# Altium schematic sheet 10-DashLEDs.SchDoc(10-DashLEDs)
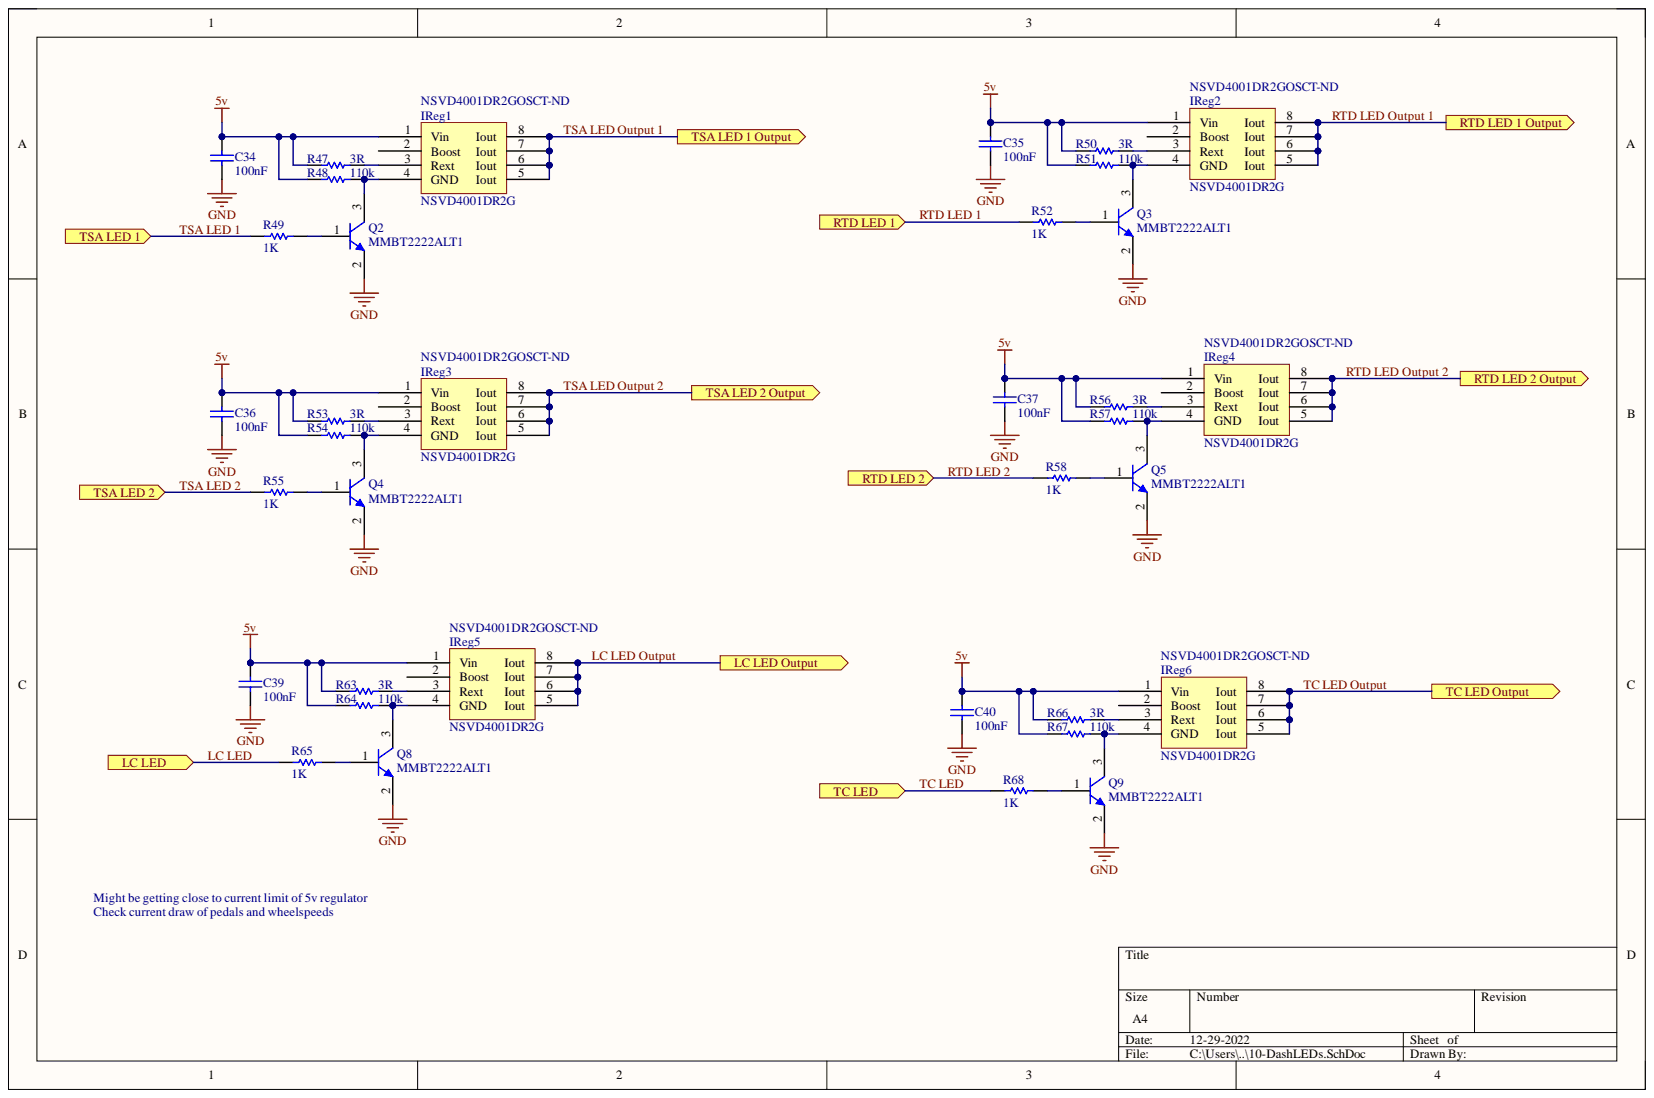
[diagram p0: 10-DashLEDs.SchDoc]

## Parts
C34  value 100nF  [1608[0603]]  (hidden comment: Cap)
C35  value 100nF  [1608[0603]]  (hidden comment: Cap)
C36  value 100nF  [1608[0603]]  (hidden comment: Cap)
C37  value 100nF  [1608[0603]]  (hidden comment: Cap)
C39  value 100nF  [1608[0603]]  (hidden comment: Cap)
C40  value 100nF  [1608[0603]]  (hidden comment: Cap)
IReg1  NSVD4001DR2G  Supplier Part Number 1: NSVD4001DR2GOSCT-ND  [SO8_M]
IReg2  NSVD4001DR2G  Supplier Part Number 1: NSVD4001DR2GOSCT-ND  [SO8_M]
IReg3  NSVD4001DR2G  Supplier Part Number 1: NSVD4001DR2GOSCT-ND  [SO8_M]
IReg4  NSVD4001DR2G  Supplier Part Number 1: NSVD4001DR2GOSCT-ND  [SO8_M]
IReg5  NSVD4001DR2G  Supplier Part Number 1: NSVD4001DR2GOSCT-ND  [SO8_M]
IReg6  NSVD4001DR2G  Supplier Part Number 1: NSVD4001DR2GOSCT-ND  [SO8_M]
Q2  MMBT2222ALT1  [ONSC-SOT-23-3-318-08_V]
Q3  MMBT2222ALT1  [ONSC-SOT-23-3-318-08_V]
Q4  MMBT2222ALT1  [ONSC-SOT-23-3-318-08_V]
Q5  MMBT2222ALT1  [ONSC-SOT-23-3-318-08_V]
Q8  MMBT2222ALT1  [ONSC-SOT-23-3-318-08_V]
Q9  MMBT2222ALT1  [ONSC-SOT-23-3-318-08_V]
R47  value 3R  [1608[0603]]  (hidden comment: Res1)
R48  value 110k  Resistance (Ohms): 110k  [1608[0603]]  (hidden comment: Res1)
R49  value 1K  [1608[0603]]  (hidden comment: Res1)
R50  value 3R  [1608[0603]]  (hidden comment: Res1)
R51  value 110k  Resistance (Ohms): 110k  [1608[0603]]  (hidden comment: Res1)
R52  value 1K  [1608[0603]]  (hidden comment: Res1)
R53  value 3R  [1608[0603]]  (hidden comment: Res1)
R54  value 110k  Resistance (Ohms): 110k  [1608[0603]]  (hidden comment: Res1)
R55  value 1K  [1608[0603]]  (hidden comment: Res1)
R56  value 3R  [1608[0603]]  (hidden comment: Res1)
R57  value 110k  Resistance (Ohms): 110k  [1608[0603]]  (hidden comment: Res1)
R58  value 1K  [1608[0603]]  (hidden comment: Res1)
R63  value 3R  [1608[0603]]  (hidden comment: Res1)
R64  value 110k  Resistance (Ohms): 110k  [1608[0603]]  (hidden comment: Res1)
R65  value 1K  [1608[0603]]  (hidden comment: Res1)
R66  value 3R  [1608[0603]]  (hidden comment: Res1)
R67  value 110k  Resistance (Ohms): 110k  [1608[0603]]  (hidden comment: Res1)
R68  value 1K  [1608[0603]]  (hidden comment: Res1)

## Ports
LC LED, LC LED Output, RTD LED 1, RTD LED 1 Output, RTD LED 2, RTD LED 2 Output, TC LED, TC LED Output, TSA LED 1, TSA LED 1 Output, TSA LED 2, TSA LED 2 Output

## Nets
5v: C34.2 C35.2 C36.2 C37.2 C39.2 C40.2 IReg1.1(Vin) IReg2.1(Vin) IReg3.1(Vin) IReg4.1(Vin) IReg5.1(Vin) IReg6.1(Vin) R47.1 R48.1 R50.1 R51.1 R53.1 R54.1 R56.1 R57.1 R63.1 R64.1 R66.1 R67.1
GND: C34.1 C35.1 C36.1 C37.1 C39.1 C40.1 Q2.2 Q3.2 Q4.2 Q5.2 Q8.2 Q9.2
LC LED: R65.2 ; port LC LED
LC LED Output: IReg5.5(Iout) IReg5.6(Iout) IReg5.7(Iout) IReg5.8(Iout) ; port LC LED Output
RTD LED 1: R52.2 ; port RTD LED 1
RTD LED 2: R58.2 ; port RTD LED 2
RTD LED Output 1: IReg2.5(Iout) IReg2.6(Iout) IReg2.7(Iout) IReg2.8(Iout) ; port RTD LED 1 Output
RTD LED Output 2: IReg4.5(Iout) IReg4.6(Iout) IReg4.7(Iout) IReg4.8(Iout) ; port RTD LED 2 Output
TC LED: R68.2 ; port TC LED
TC LED Output: IReg6.5(Iout) IReg6.6(Iout) IReg6.7(Iout) IReg6.8(Iout) ; port TC LED Output
TSA LED 1: R49.2 ; port TSA LED 1
TSA LED 2: R55.2 ; port TSA LED 2
TSA LED Output 1: IReg1.5(Iout) IReg1.6(Iout) IReg1.7(Iout) IReg1.8(Iout) ; port TSA LED 1 Output
TSA LED Output 2: IReg3.5(Iout) IReg3.6(Iout) IReg3.7(Iout) IReg3.8(Iout) ; port TSA LED 2 Output
NetIReg1_3: IReg1.3(Rext) R47.2
NetIReg1_4: IReg1.4(GND) Q2.3 R48.2
NetIReg2_3: IReg2.3(Rext) R50.2
NetIReg2_4: IReg2.4(GND) Q3.3 R51.2
NetIReg3_3: IReg3.3(Rext) R53.2
NetIReg3_4: IReg3.4(GND) Q4.3 R54.2
NetIReg4_3: IReg4.3(Rext) R56.2
NetIReg4_4: IReg4.4(GND) Q5.3 R57.2
NetIReg5_3: IReg5.3(Rext) R63.2
NetIReg5_4: IReg5.4(GND) Q8.3 R64.2
NetIReg6_3: IReg6.3(Rext) R66.2
NetIReg6_4: IReg6.4(GND) Q9.3 R67.2
NetQ2_1: Q2.1 R49.1
NetQ3_1: Q3.1 R52.1
NetQ4_1: Q4.1 R55.1
NetQ5_1: Q5.1 R58.1
NetQ8_1: Q8.1 R65.1
NetQ9_1: Q9.1 R68.1

Not connected: IReg1 2(Boost); IReg2 2(Boost); IReg3 2(Boost); IReg4 2(Boost); IReg5 2(Boost); IReg6 2(Boost)

## Library data of the parts
C34-C37 C39 C40 = Cap: Capacitor; Manufacturer: Yageo; Manufacturer Part Number: CC0603KRX7R9BB104; Capacitance: 0.10µF; Temperature Coefficient: X7R; Tolerance: ±10%; Voltage - Rated: 50V; Applications: General Purpose; Category: Capacitors; Component Kind: Standard; ComponentLink1Description: http://www.yageo.com/documents/recent/UPY-GPHC_X7R_6.3V-to-50V_16.pdf; ComponentLink1URL: http://www.yageo.com/documents/recent/UPY-GPHC_X7R_6.3V-to-50V_16.pdf; Failure Rate: -; Features: -; Height - Seated (Max): -; Lead Spacing: -; Lead Style: -; Library Name: Miscellaneous Devices.IntLib; Mounting Type: Surface Mount, MLCC; Operating Temperature: -55°C ~ 125°C; Package / Case: 0603 (1608 Metric); Packaging: Cut Tape (CT); Part Status: Active; Pricing 1: 1=0.15, 10=0.043, 25=0.0312, 50=0.0232, 100=0.0189, 250=0.0158, 500=0.01346, 1000=0.01059 (CAD); Ratings: -; RoHS: RoHS Compliant; Simulation: CAP; Size / Dimension: 0.063" L x 0.031" W (1.60mm x 0.80mm); Stock 1: 4016262; Supplier 1: Digi-Key; Supplier Part Number 1: 311-1344-1-ND; Thickness (Max): 0.035" (0.90mm)
IReg1-IReg6 = LED Currnet Driver, 500mA, 30V max: Manufacturer: ON Semiconductor; Manufacturer Part Number: NUD4001DR2G; Current - Output / Channel: 500mA; Dimming: PWM; Internal Switch(s): Yes; Number of Outputs: 1; Voltage - Output: 28V; Voltage - Supply (Max): 30V; Voltage - Supply (Min): 3.6V; Applications: Automotive, Lighting; Category: Integrated Circuits (ICs); Component Kind: Standard; ComponentLink1Description: http://www.onsemi.com/pub_link/Collateral/NUD4001-D.PDF; ComponentLink1URL: http://www.onsemi.com/pub_link/Collateral/NUD4001-D.PDF; Frequency: -; Mounting Type: Surface Mount; Operating Temperature: -40°C ~ 125°C (TA); Package / Case: 8-SOIC (0.154", 3.90mm Width); Packaging: Cut Tape (CT); Part Status: Active; Pricing 1: 1=1.36, 10=1.197, 100=0.9174, 500=0.72524, 1000=0.58019 (CAD); RoHS: RoHS Compliant; Stock 1: 212669; Supplier 1: Digi-Key; Supplier Device Package: 8-SOIC; Topology: -; Type: Linear; Library Name: VCU Library.SchLib
Q2-Q5 Q8 Q9 = CMP-1048-00593-1: General Purpose Transistor, NPN Silicon, 3-Pin SOT-23, Tape and Reel; Manufacturer: On Semiconductor; fT Min (MHz): 300; IC Continuous (A): 0.6; Component Kind: Standard; ComponentLink1Description: Manufacturer URL; ComponentLink1URL: http://www.onsemi.com/; ComponentLink2Description: Datasheet; ComponentLink2URL: http://www.onsemi.cn/pub_link/Collateral/MMBT2222LT1-D.PDF; ComponentLink3Description: Package Specification; ComponentLink3URL: http://www.onsemi.cn/pub_link/Collateral/318-08.PDF; DatasheetVersion: Rev. 10; Library Name: Altium Content Vault; Mounting Technology: Surface Mount; PackageDescription: 3-Pin Small Outline Transistor, Body 2.92 x 1.3 mm, Pitch 0.95 mm; PackageReference: SOT-23-3-318-08; PackageVersion: Rev. AP, 11/2009; Packing: Tape and Reel; PartNumber: MMBT2222ALT1; Polarity: NPN; RoHS: FALSE
R47 R50 R53 R56 R63 R66 = Res1: Resistor; Manufacturer: Yageo; Manufacturer Part Number: RC0603FR-072RL; Power (Watts): 0.1W, 1/10W; Resistance (Ohms): 2; Temperature Coefficient: ±200ppm/°C; Tolerance: ±1%; Category: Resistors; Component Kind: Standard; ComponentLink1Description: http://www.yageo.com/NewPortal/yageodocoutput?fileName=/pdf/R-Chip/PYu-RC_Group_51_RoHS_L_4.pdf; ComponentLink1URL: http://www.yageo.com/NewPortal/yageodocoutput?fileName=/pdf/R-Chip/PYu-RC_Group_51_RoHS_L_4.pdf; Composition: Thick Film; Failure Rate: -; Features: Moisture Resistant; Height - Seated (Max): 0.022" (0.55mm); Library Name: Miscellaneous Devices.IntLib; Number of Terminations: 2; Operating Temperature: -55°C ~ 155°C; Package / Case: 0603 (1608 Metric); Packaging: Cut Tape (CT); Part Status: Active; Pricing 1: 1=0.16, 10=0.03, 25=0.022, 50=0.0166, 100=0.0122, 250=0.00936, 500=0.00746, 1000=0.0055 (CAD); RoHS: RoHS Compliant; Simulation: RESISTOR; Size / Dimension: 0.063" L x 0.031" W (1.60mm x 0.80mm); Stock 1: 84378; Supplier 1: Digi-Key; Supplier Device Package: 0603; Supplier Part Number 1: 311-2.00HRCT-ND
R48 R51 R54 R57 R64 R67 = Res1: Resistor; Manufacturer: Yageo; Manufacturer Part Number: RC0603JR-07110KL; Power (Watts): 0.1W, 1/10W; Temperature Coefficient: ±100ppm/°C; Tolerance: ±5%; Category: Resistors; Component Kind: Standard; ComponentLink1Description: http://www.yageo.com/NewPortal/yageodocoutput?fileName=/pdf/R-Chip/PYu-RC_Group_51_RoHS_L_4.pdf; ComponentLink1URL: http://www.yageo.com/NewPortal/yageodocoutput?fileName=/pdf/R-Chip/PYu-RC_Group_51_RoHS_L_4.pdf; Composition: Thick Film; Failure Rate: -; Features: Moisture Resistant; Height - Seated (Max): 0.022" (0.55mm); Library Name: Miscellaneous Devices.IntLib; Number of Terminations: 2; Operating Temperature: -55°C ~ 155°C; Package / Case: 0603 (1608 Metric); Packaging: Cut Tape (CT); Part Status: Active; Pricing 1: 1=0.16, 10=0.016, 25=0.0112, 50=0.0084, 100=0.0062, 250=0.0048, 500=0.00382, 1000=0.00281 (CAD); RoHS: RoHS Compliant; Simulation: RESISTOR; Size / Dimension: 0.063" L x 0.031" W (1.60mm x 0.80mm); Stock 1: 85509; Supplier 1: Digi-Key; Supplier Device Package: 0603; Supplier Part Number 1: 311-110KGRCT-ND
R49 R52 R55 R58 R65 R68 = Res1: Resistor; Manufacturer: Panasonic Electronic Components; Manufacturer Part Number: ERJ-PA3F1001V; Power (Watts): 0.25W, 1/4W; Temperature Coefficient: ±100ppm/°C; Tolerance: ±1%; Category: Resistors; Component Kind: Standard; ComponentLink1Description: https://industrial.panasonic.com/cdbs/www-data/pdf/RDA0000/AOA0000C243.pdf; ComponentLink1URL: https://industrial.panasonic.com/cdbs/www-data/pdf/RDA0000/AOA0000C243.pdf; Composition: Thick Film; Failure Rate: -; Features: Automotive AEC-Q200, Pulse Withstanding; Height - Seated (Max): 0.022" (0.55mm); Library Name: Miscellaneous Devices.IntLib; Number of Terminations: 2; Operating Temperature: -55°C ~ 155°C; Package / Case: 0603 (1608 Metric); Packaging: Cut Tape (CT); Part Status: Active; Pricing 1: 1=0.22, 10=0.198, 25=0.138, 50=0.1072, 100=0.0765, 250=0.05972, 500=0.04746, 1000=0.03368 (CAD); Resistance (Ohms): 1k; RoHS: RoHS Compliant; Simulation: RESISTOR; Size / Dimension: 0.063" L x 0.031" W (1.60mm x 0.80mm); Stock 1: 56110; Supplier 1: Digi-Key; Supplier Device Package: 0603; Supplier Part Number 1: P1.0KBYCT-ND
